# Altium schematic sheet [09] HDMI.SchDoc(BLK4)
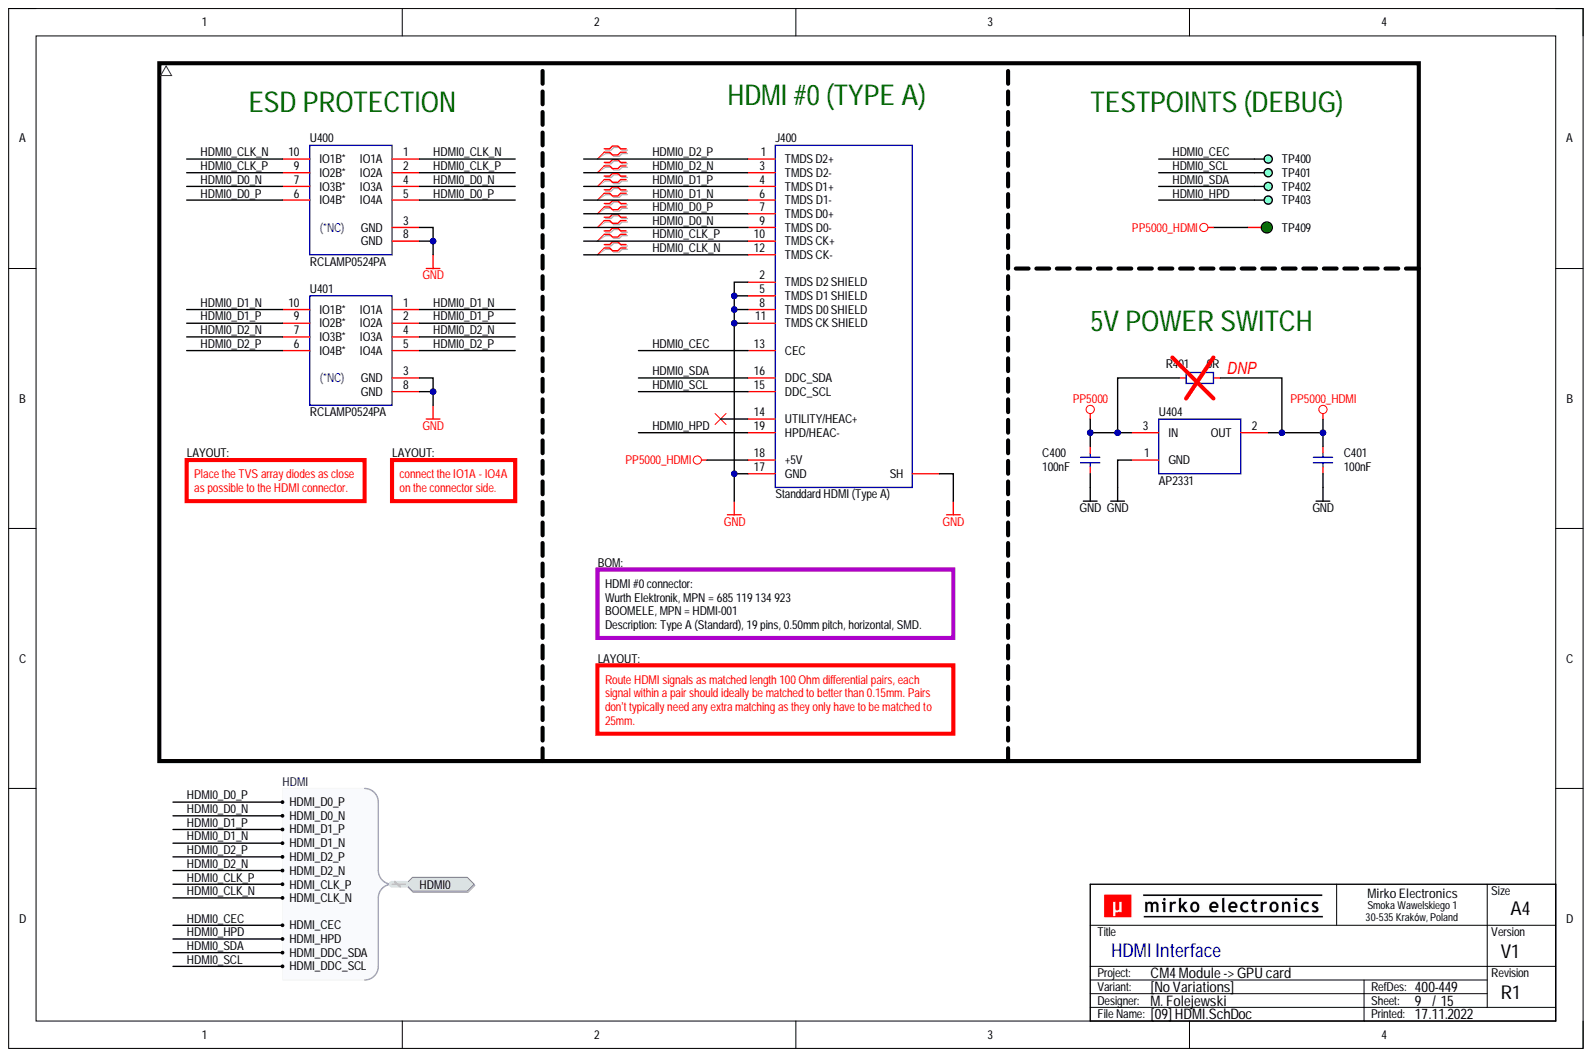
[diagram p0: [09] HDMI.SchDoc]

## Parts
C400  100nF  [CAP_0603_R]
C401  100nF  [CAP_0603_R]
J400  Standdard HDMI (Type A)  Component Subtype: HDMI (Type A)  [CON_HDMI_STD]
R401  0R  [RES_0603_R]
TP400  DEBUG  [PCB_TP_DBG_50]  (value not drawn)  (no BOM)
TP401  DEBUG  [PCB_TP_DBG_50]  (value not drawn)  (no BOM)
TP402  DEBUG  [PCB_TP_DBG_50]  (value not drawn)  (no BOM)
TP403  DEBUG  [PCB_TP_DBG_50]  (value not drawn)  (no BOM)
TP409  ICT  [PCB_TP_ICT_100]  (value not drawn)  (no BOM)
U400  RCLAMP0524PA  [ICC_SLP2510P8_250X100X60_P50_R]
U401  RCLAMP0524PA  [ICC_SLP2510P8_250X100X60_P50_R]
U404  AP2331  [PKG_SOT23-3L_SC59_P190_R]

## Ports
HDMI0, HDMI0.HDMI_CEC, HDMI0.HDMI_CLK_N, HDMI0.HDMI_CLK_P, HDMI0.HDMI_D0_N, HDMI0.HDMI_D0_P, HDMI0.HDMI_D1_N, HDMI0.HDMI_D1_P, HDMI0.HDMI_D2_N, HDMI0.HDMI_D2_P, HDMI0.HDMI_DDC_SCL, HDMI0.HDMI_DDC_SDA, HDMI0.HDMI_HPD

## Nets
GND: C400.1 C401.1 J400.2(TMDS_D2_SHIELD) J400.5(TMDS_D1_SHIELD) J400.8(TMDS_D0_SHIELD) J400.11(TMDS_CK_SHIELD) J400.17(GND) J400.SH U400.3(GND) U400.8(GND) U401.3(GND) U401.8(GND) U404.1
PP5000: C400.2 R401.2 U404.3
PP5000_HDMI: C401.2 J400.18(+5V) R401.1 TP409.1 U404.2
HDMI0_CEC: J400.13(CEC) TP400.1 ; port HDMI0
HDMI0_CLK_N: J400.12(TMDS_CK-) U400.1(IO1A) U400.10(IO1B*) ; port HDMI0
HDMI0_CLK_P: J400.10(TMDS_CK+) U400.2(IO2A) U400.9(IO2B*) ; port HDMI0
HDMI0_D0_N: J400.9(TMDS_D0-) U400.4(IO3A) U400.7(IO3B*) ; port HDMI0
HDMI0_D0_P: J400.7(TMDS_D0+) U400.5(IO4A) U400.6(IO4B*) ; port HDMI0
HDMI0_D1_N: J400.6(TMDS_D1-) U401.1(IO1A) U401.10(IO1B*) ; port HDMI0
HDMI0_D1_P: J400.4(TMDS_D1+) U401.2(IO2A) U401.9(IO2B*) ; port HDMI0
HDMI0_D2_N: J400.3(TMDS_D2-) U401.4(IO3A) U401.7(IO3B*) ; port HDMI0
HDMI0_D2_P: J400.1(TMDS_D2+) U401.5(IO4A) U401.6(IO4B*) ; port HDMI0
HDMI0_HPD: J400.19(HPD/HEAC-) TP403.1 ; port HDMI0
HDMI0_SCL: J400.15(DDC_SCL) TP401.1 ; port HDMI0
HDMI0_SDA: J400.16(DDC_SDA) TP402.1 ; port HDMI0

Not connected: J400 14(UTILITY/HEAC+)

## Library data of the parts
C400 C401 = CAP-0008: Capacitor; MLCC; 100nF; TBD; TBD; TBD; TBD; SMD; 0603 (1608);; Database Table Name: CAPACITOR$; Component Kind: Standard; Component Subtype: MLCC; Component Type: Capacitor; Manufacturer: TBD; Mounting Type: SMD; MPN: TBD; Package (Case): 0603 (1608); Supplier: ?; Value: 100nF; Library Name: MirFol_db_local.DbLib
J400 = CON_HDMI_1.4+_A2: Connector; HDMI v1.4+;; Manufacturer: BOOMELE; MPN: HDMI-001; Package (Case): SMD; Component Kind: Standard; Component Type: Connector; Mounting Type: SMD; Supplier: ?; Value: Standdard HDMI (Type A); Library Name: MirFol_lib.SchLib
R401 = RES-0054: Resistor; Thick Film; GENERIC; TBD; TBD; TBD; TBD; TBD; SMD; 0603 (1608);; Database Table Name: RESISTOR$; Component Kind: Standard; Component Subtype: Thick Film; Component Type: Resistor; Manufacturer: GENERIC; Mounting Type: SMD; MPN: GENERIC; Package (Case): 0603 (1608); Supplier: ?; Value: 0R; Library Name: MirFol_db_local.DbLib
TP400-TP403 = PCB_TP_DBG: PCB Marking; Test Point (Debug);; Component Subtype: -; Component Type: Testpoint; Mounting Type: -; Supplier: ?; Value: DEBUG; Library Name: MirFol_lib.SchLib
TP409 = PCB_TP_ICT: Test Point (ICT); Specification: Testpoint (for ICT), SMD pad; Component Type: Testpoint (for ICT); Manufacturer: -; Mounting Type: Non component; MPN: -; Value: ICT; Library Name: MirFol_lib.SchLib
U400 U401 = ICC-0005: IC; TVS Arrays; ESD Protection; 4-Channel; 17kV Air; 12kV Contact; 0.3pF Capitance; TBD; SMD; TBD;; Manufacturer: Semtech Corporation; MPN: RCLAMP0524PATCT; Database Table Name: IC (MISC)$; Component Kind: Standard; Component Subtype: TVS Arrays; Component Type: IC; Mounting Type: SMD; Package (Case): TBD; Supplier: ?; Value: RCLAMP0524PA; Library Name: MirFol_db_local.DbLib
U404 = ICC-0008: IC; Power Switcher; TBD TBD; SMD; SC59;; Manufacturer: Diodes Incorporated; MPN: AP2331W-7; Database Table Name: IC (MISC)$; Component Kind: Standard; Component Subtype: Power Switcher; Component Type: IC; Mounting Type: SMD; Package (Case): SC59; Supplier: ?; Value: AP2331; Library Name: MirFol_db_local.DbLib
